# Altium schematic sheet Core.SchDoc
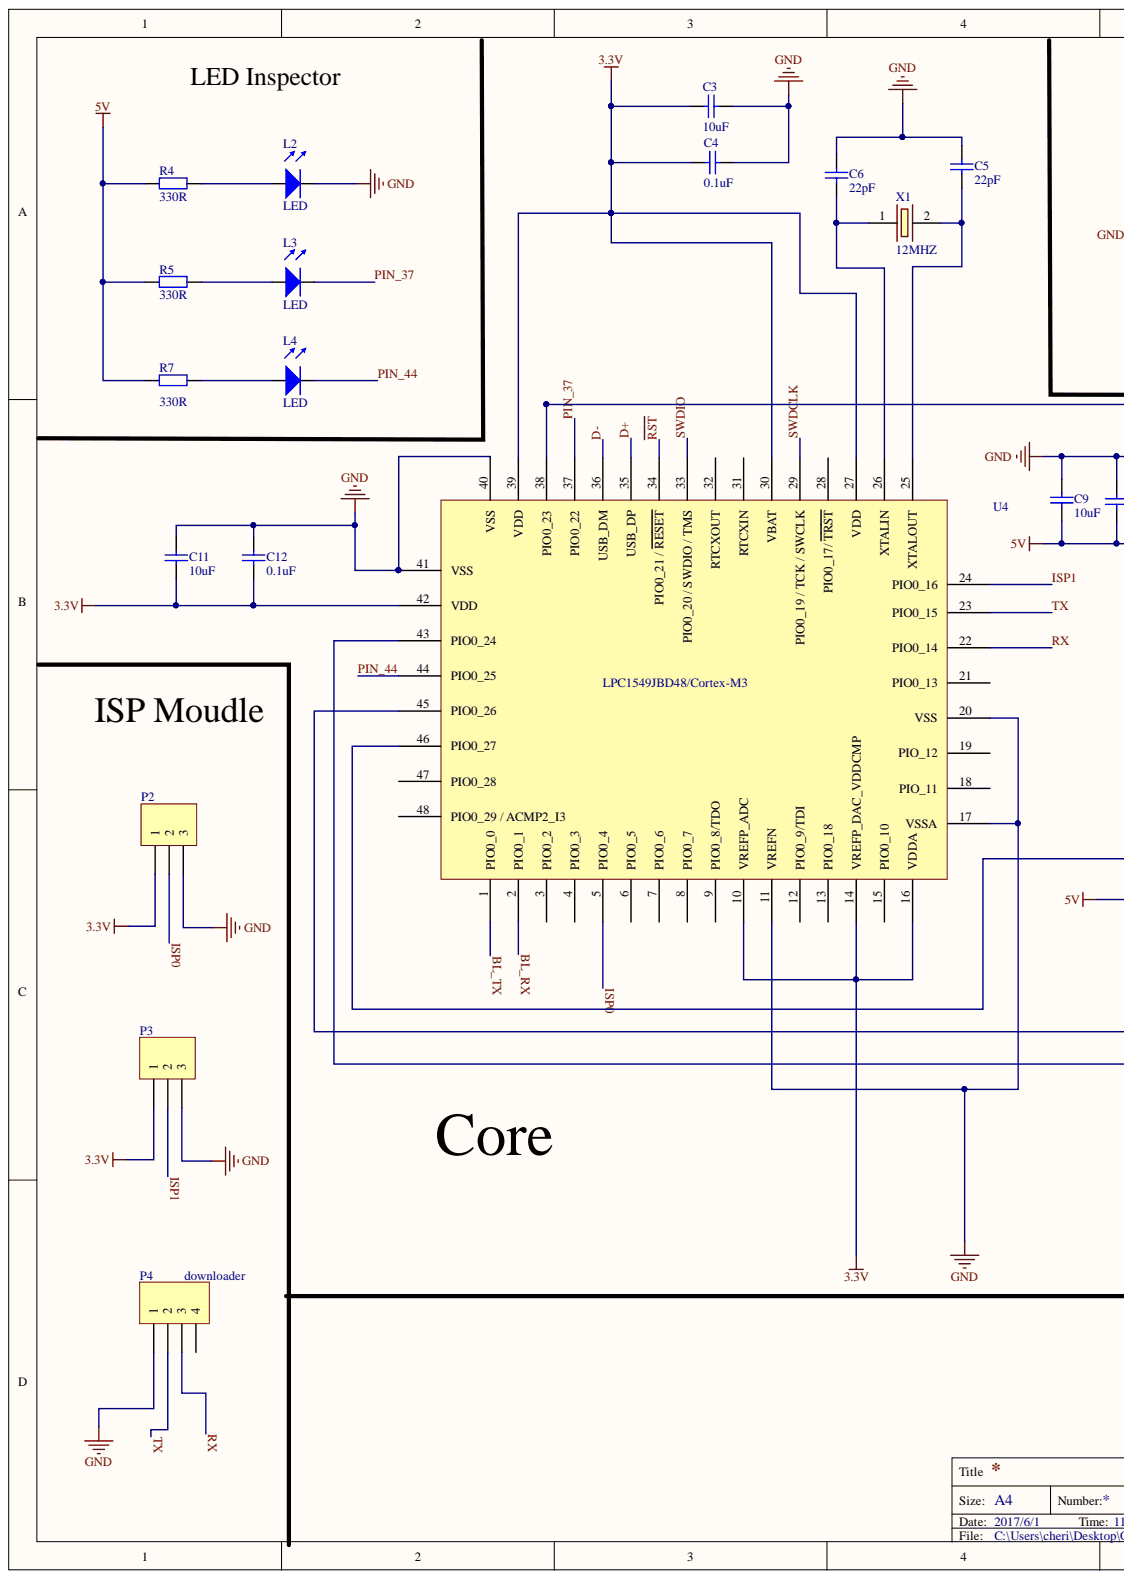
[diagram p0: Core.SchDoc, left]
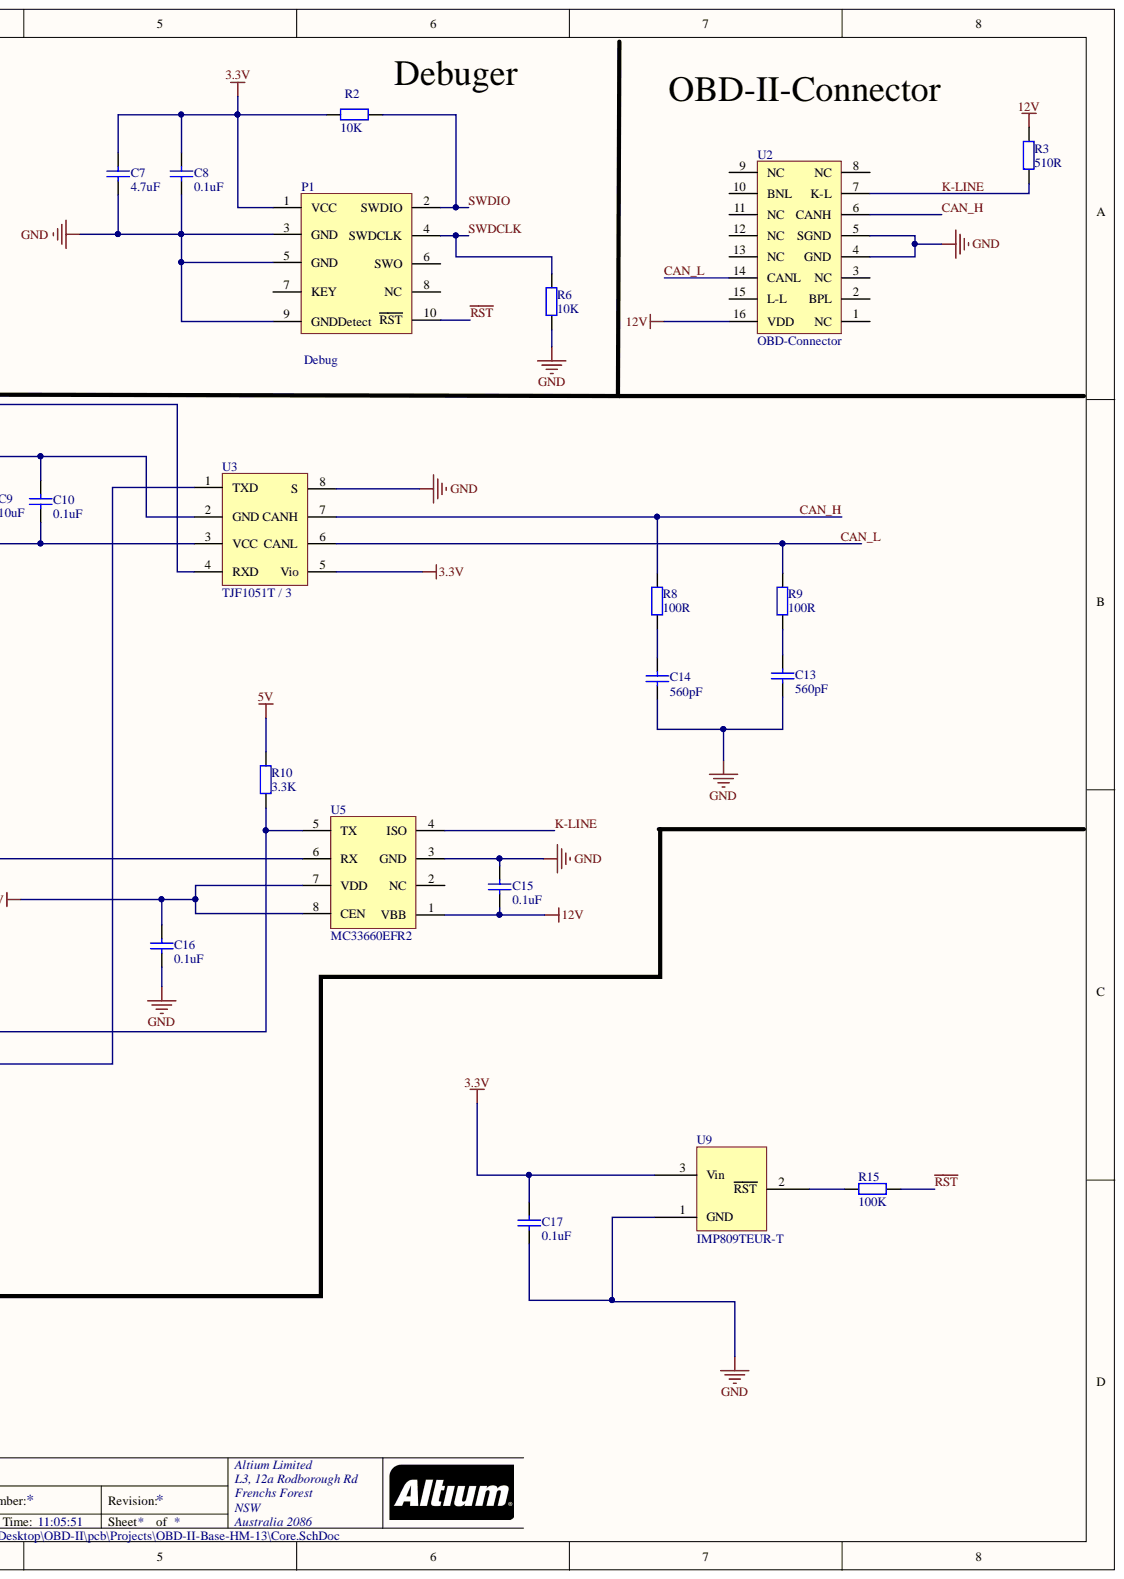
[diagram p1: Core.SchDoc, right]

## Parts
C3  10uF  [0603]
C4  0.1uF  [0603]
C5  22pF  [0603]
C6  22pF  [0603]
C7  4.7uF  [0603]
C8  0.1uF  [0603]
C9  10uF  [0603]
C10  0.1uF  [0603]
C11  10uF  [0603]
C12  0.1uF  [0603]
C13  560pF  [0603]
C14  560pF  [0603]
C15  0.1uF  [0603]
C16  0.1uF  [0603]
C17  0.1uF  [0603]
L2  LED  [0805]
L3  LED  [0805]
L4  LED  [0805]
P1  Debug  [JATG_10pin]
P2  (no value)  [HDR1X3]
P3  (no value)  [HDR1X3]
P4  downloader  [HDR1X4]
R2  10K  [0603]
R3  510R  [0603]
R4  330R  [0603]
R5  330R  [0603]
R6  10K  [0603]
R7  330R  [0603]
R8  100R  [0603]
R9  100R  [0603]
R10  3.3K  [0603]
R15  100K  [0603]
U2  OBD-Connector  [OBD-MaleConnector]
U3  TJF1051T / 3  [TJF1051]
U4  LPC1549  [LPC1549JBD48]
U5  MC33660EFR2  [SOP-8]
U9  IMP809TEUR-T  [SOT23-3]
X1  12MHZ  [12Mhz-DFN]

## Nets
3.3V: C3.2 C4.2 C7.2 C8.2 C11.1 C12.1 C17.2 P1.1(VCC) P2.1 P3.1 R2.1 U3.5(Vio) U4.10(VREFP_ADC) U4.14(VREFP_DAC_VDDCMP) U4.16(VDDA) U4.27(VDD) U4.30(VBAT) U4.39(VDD) U4.42(VDD) U9.3
5V: C9.1 C10.1 C16.2 R4.1 R5.1 R7.1 R10.2 U3.3(VCC) U5.7(VDD) U5.8(CEN)
12V: C15.1 R3.2 U2.16(VDD) U5.1(VBB)
GND: C3.1 C4.1 C5.2 C6.2 C7.1 C8.1 C9.2 C10.2 C11.2 C12.2 C13.1 C14.1 C15.2 C16.1 C17.1 L2.2 P1.3(GND) P1.5(GND) P1.9(GNDDetect) P2.3 P3.3 P4.1 R6.1 U2.4(GND) U2.5(SGND) U3.2(GND) U3.8(S) U4.11(VREFN) U4.17(VSSA) U4.20(VSS) U4.40(VSS) U4.41(VSS) U5.3(GND) U9.1
BL_RX: U4.2
BL_TX: U4.1
CAN_H: R8.2 U2.6(CANH) U3.7(CANH)
CAN_L: R9.2 U2.14(CANL) U3.6(CANL)
D+: U4.35(USB_DP)
D-: U4.36(USB_DM)
ISP0: P2.2 U4.5
ISP1: P3.2 U4.24
K-LINE: R3.1 U2.7(K-L) U5.4(ISO)
PIN_37: L3.2 U4.37
PIN_44: L4.2 U4.44
~{RST}: P1.10(RST) R15.1 U4.34(/_RESET)
RX: P4.3 U4.22
SWDCLK: P1.4(SWDCLK) R6.2 U4.29(/_TCK_/_SWCLK)
SWDIO: P1.2(SWDIO) R2.2 U4.33(/_SWDIO_/_TMS)
TX: P4.2 U4.23
NetC5_1: C5.1 U4.25(XTALOUT) X1.2
NetC6_1: C6.1 U4.26(XTALIN) X1.1
NetC13_2: C13.2 R9.1
NetC14_2: C14.2 R8.1
NetL2_1: L2.1 R4.2
NetL3_1: L3.1 R5.2
NetL4_1: L4.1 R7.2
NetR10_1: R10.1 U4.45 U5.5(TX)
NetR15_2: R15.2 U9.2
NetU3_1: U3.1(TXD) U4.43
NetU3_4: U3.4(RXD) U4.38
NetU4_46: U4.46 U5.6(RX)

Not connected: P1 6(SWO) 7(KEY) 8(NC); P4 4; U2 1(NC) 2(BPL) 3(NC) 8(NC) 9(NC) 10(BNL) 11(NC) 12(NC) 13(NC) 15(L-L); U4 3 4 6 7 8 9 12 13 15 18(PIO_11) 19(PIO_12) 21 28(TRST) 31(RTCXIN) 32(RTCXOUT) 47 48; U5 2(NC)

## Library data of the parts
C3-C17 = Cap1: ceramic; Component Kind: Standard; Library Name: ToponLib.IntLib
P1 = JTAG_10Pin: Component Kind: Standard; Library Name: ToponLib.IntLib
P2 P3 = Header 3: Header, 3-Pin; Component Kind: Standard; LatestRevisionDate: 17-Jul-2002; LatestRevisionNote: Re-released for DXP Platform.; Library Name: Miscellaneous Connectors.IntLib; Signal Integrity: Connector; Publisher: Altium Limited
P4 = Header 4: Header, 4-Pin; Component Kind: Standard; LatestRevisionDate: 17-Jul-2002; LatestRevisionNote: Re-released for DXP Platform.; Library Name: Miscellaneous Connectors.IntLib; Signal Integrity: Connector; Publisher: Altium Limited
R2-R10 R15 = Res: Component Kind: Standard; Library Name: ToponLib.IntLib
U2 = OBD-MaleHead: Component Kind: Standard; Library Name: ToponLib.IntLib
U4 = LPC1549JBD48: Component Kind: Standard; Library Name: ToponLib.IntLib
X1 = OSC: Component Kind: Standard; Library Name: ToponLib.IntLib
L2-L4 = LED: Component Kind: Standard; Library Name: ToponLib.IntLib
U3 = TJF1051T / 3: Component Kind: Standard; Library Name: ToponLib.IntLib
U5 = MC33660EFR2: Component Kind: Standard; Library Name: ToponLib.IntLib
U9 = IMP809TEUR-T: Component Kind: Standard; Library Name: ToponLib.IntLib
